# Altium schematic sheet 06-Front Panel SFP_2.SchDoc("06-Front Panel SFP_2")
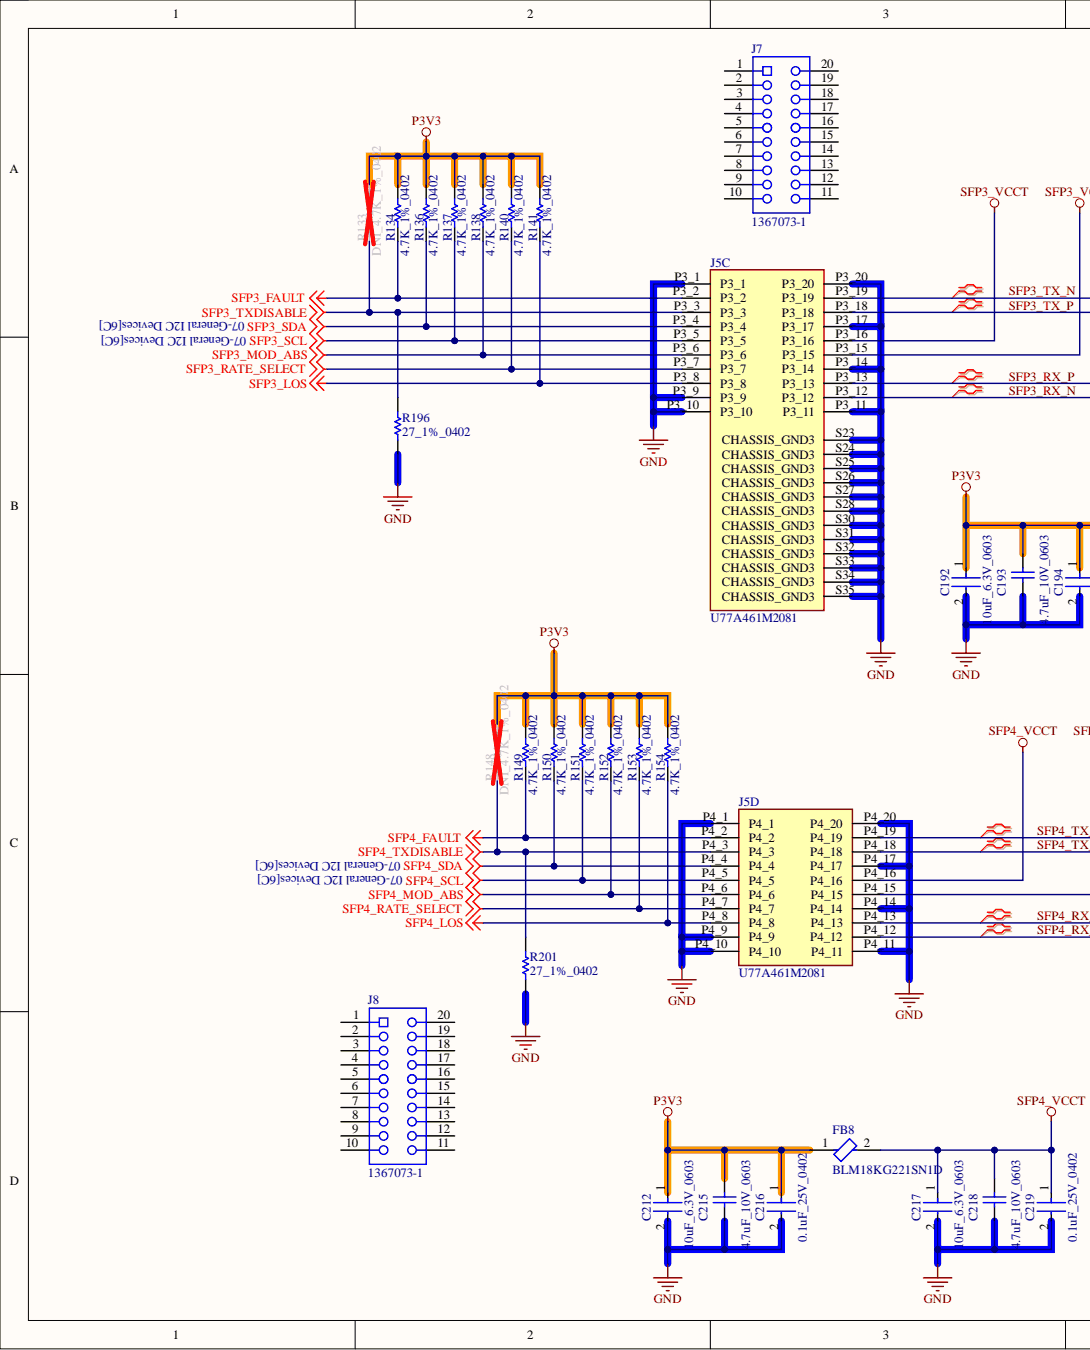
[diagram p0: 06-Front Panel SFP_2.SchDoc, left]
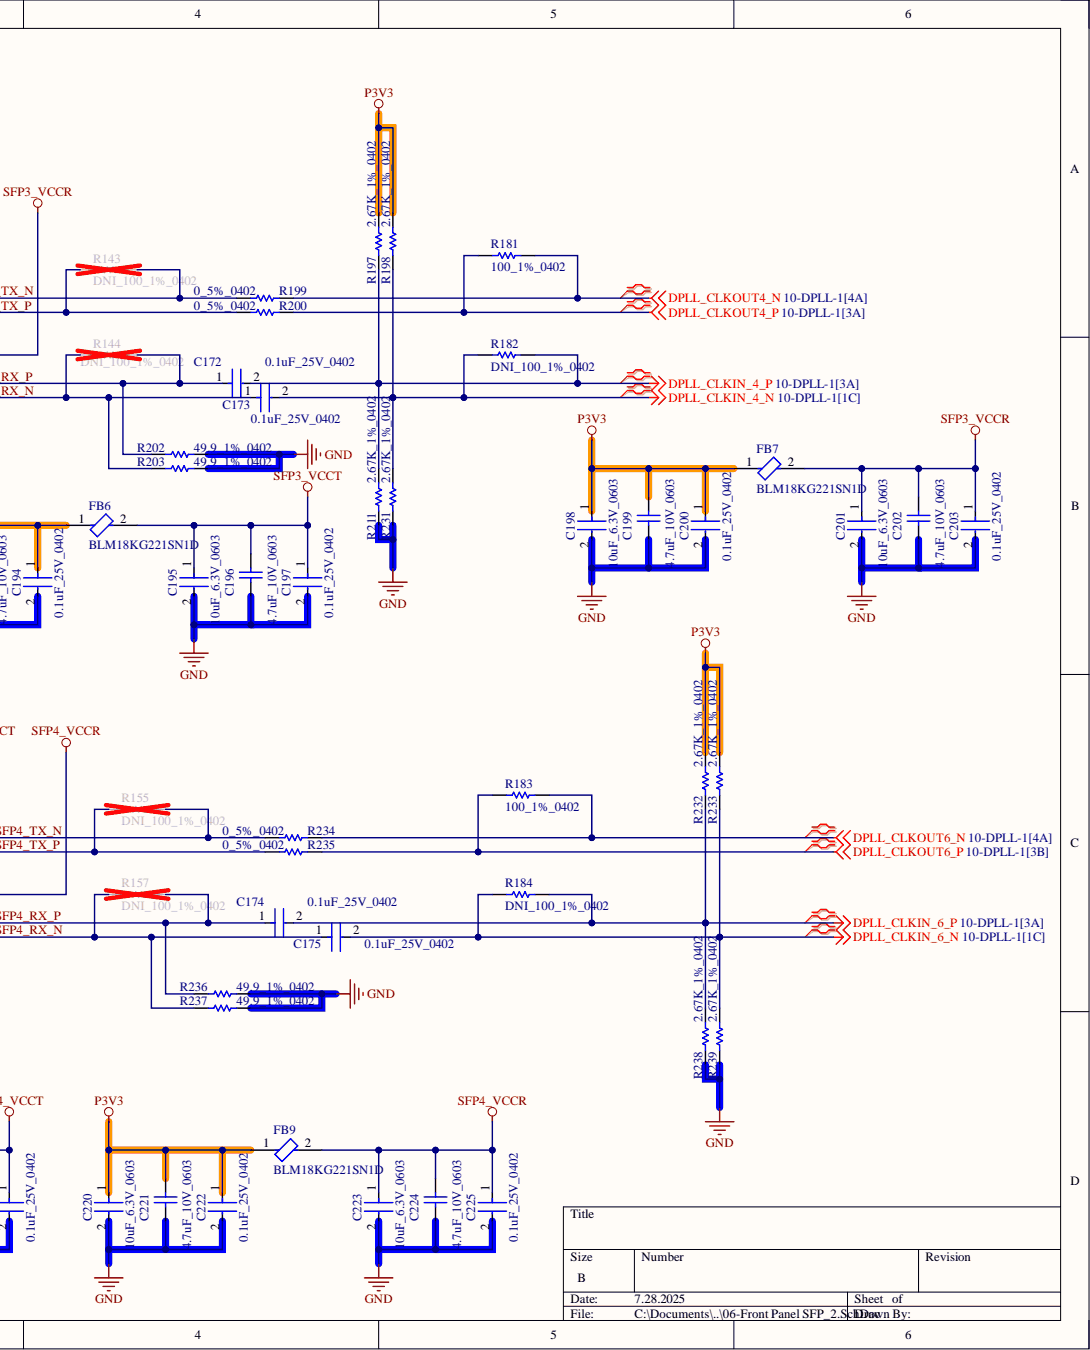
[diagram p1: 06-Front Panel SFP_2.SchDoc, right]

## Parts
C172  0.1uF_25V_0402  [FP-0402-L_1_0_1-W_0_5_0_1-IPC_C]
C173  0.1uF_25V_0402  [FP-0402-L_1_0_1-W_0_5_0_1-IPC_C]
C174  0.1uF_25V_0402  [FP-0402-L_1_0_1-W_0_5_0_1-IPC_C]
C175  0.1uF_25V_0402  [FP-0402-L_1_0_1-W_0_5_0_1-IPC_C]
C192  10uF_6.3V_0603  [FP-C0603C106M9PACTU-MFG]
C193  4.7uF_10V_0603  [CAPC1608X87X35NL15T15]
C194  0.1uF_25V_0402  [FP-0402-L_1_0_1-W_0_5_0_1-IPC_C]
C195  10uF_6.3V_0603  [FP-C0603C106M9PACTU-MFG]
C196  4.7uF_10V_0603  [CAPC1608X87X35NL15T15]
C197  0.1uF_25V_0402  [FP-0402-L_1_0_1-W_0_5_0_1-IPC_C]
C198  10uF_6.3V_0603  [FP-C0603C106M9PACTU-MFG]
C199  4.7uF_10V_0603  [CAPC1608X87X35NL15T15]
C200  0.1uF_25V_0402  [FP-0402-L_1_0_1-W_0_5_0_1-IPC_C]
C201  10uF_6.3V_0603  [FP-C0603C106M9PACTU-MFG]
C202  4.7uF_10V_0603  [CAPC1608X87X35NL15T15]
C203  0.1uF_25V_0402  [FP-0402-L_1_0_1-W_0_5_0_1-IPC_C]
C212  10uF_6.3V_0603  [FP-C0603C106M9PACTU-MFG]
C215  4.7uF_10V_0603  [CAPC1608X87X35NL15T15]
C216  0.1uF_25V_0402  [FP-0402-L_1_0_1-W_0_5_0_1-IPC_C]
C217  10uF_6.3V_0603  [FP-C0603C106M9PACTU-MFG]
C218  4.7uF_10V_0603  [CAPC1608X87X35NL15T15]
C219  0.1uF_25V_0402  [FP-0402-L_1_0_1-W_0_5_0_1-IPC_C]
C220  10uF_6.3V_0603  [FP-C0603C106M9PACTU-MFG]
C221  4.7uF_10V_0603  [CAPC1608X87X35NL15T15]
C222  0.1uF_25V_0402  [FP-0402-L_1_0_1-W_0_5_0_1-IPC_C]
C223  10uF_6.3V_0603  [FP-C0603C106M9PACTU-MFG]
C224  4.7uF_10V_0603  [CAPC1608X87X35NL15T15]
C225  0.1uF_25V_0402  [FP-0402-L_1_0_1-W_0_5_0_1-IPC_C]
FB6  BLM18KG221SN1D  [FP-BLM18-0_15-t0_8-IPC_B]
FB7  BLM18KG221SN1D  [FP-BLM18-0_15-t0_8-IPC_B]
FB8  BLM18KG221SN1D  [FP-BLM18-0_15-t0_8-IPC_B]
FB9  BLM18KG221SN1D  [FP-BLM18-0_15-t0_8-IPC_B]
J5  U77A461M2081  [AMPHENOL_U77A461M2081]  (parts C,D)
J7  1367073-1  [TECO-1367073-1_V]
J8  1367073-1  [TECO-1367073-1_V]
R133  DNI_4.7K_1%_0402  [RESC1005X40X25NL10T10]  (no BOM)
R134  4.7K_1%_0402  [RESC1005X40X25NL10T10]
R136  4.7K_1%_0402  [RESC1005X40X25NL10T10]
R137  4.7K_1%_0402  [RESC1005X40X25NL10T10]
R138  4.7K_1%_0402  [RESC1005X40X25NL10T10]
R140  4.7K_1%_0402  [RESC1005X40X25NL10T10]
R141  4.7K_1%_0402  [RESC1005X40X25NL10T10]
R143  DNI_100_1%_0402  [RESC1005X40X25NL05T10]  (no BOM)
R144  DNI_100_1%_0402  [RESC1005X40X25NL05T10]  (no BOM)
R148  DNI_4.7K_1%_0402  [RESC1005X40X25NL10T10]  (no BOM)
R149  4.7K_1%_0402  [RESC1005X40X25NL10T10]
R150  4.7K_1%_0402  [RESC1005X40X25NL10T10]
R151  4.7K_1%_0402  [RESC1005X40X25NL10T10]
R152  4.7K_1%_0402  [RESC1005X40X25NL10T10]
R153  4.7K_1%_0402  [RESC1005X40X25NL10T10]
R154  4.7K_1%_0402  [RESC1005X40X25NL10T10]
R155  DNI_100_1%_0402  [RESC1005X40X25NL05T10]  (no BOM)
R157  DNI_100_1%_0402  [RESC1005X40X25NL05T10]  (no BOM)
R181  100_1%_0402  [RESC1005X40X25NL05T10]
R182  DNI_100_1%_0402  [RESC1005X40X25NL05T10]  (no BOM)
R183  100_1%_0402  [RESC1005X40X25NL05T10]
R184  DNI_100_1%_0402  [RESC1005X40X25NL05T10]  (no BOM)
R196  27_1%_0402  [RESC1005X40X25NL05T05]
R197  2.67K_1%_0402  [RESC1005X40X25NL05T10]
R198  2.67K_1%_0402  [RESC1005X40X25NL05T10]
R199  0_5%_0402  [RESC1005X40X25LL10T10]
R200  0_5%_0402  [RESC1005X40X25LL10T10]
R201  27_1%_0402  [RESC1005X40X25NL05T05]
R202  49.9_1%_0402  [RESC1005X40X25NL10T10]
R203  49.9_1%_0402  [RESC1005X40X25NL10T10]
R211  2.67K_1%_0402  [RESC1005X40X25NL05T10]
R231  2.67K_1%_0402  [RESC1005X40X25NL05T10]
R232  2.67K_1%_0402  [RESC1005X40X25NL05T10]
R233  2.67K_1%_0402  [RESC1005X40X25NL05T10]
R234  0_5%_0402  [RESC1005X40X25LL10T10]
R235  0_5%_0402  [RESC1005X40X25LL10T10]
R236  49.9_1%_0402  [RESC1005X40X25NL10T10]
R237  49.9_1%_0402  [RESC1005X40X25NL10T10]
R238  2.67K_1%_0402  [RESC1005X40X25NL05T10]
R239  2.67K_1%_0402  [RESC1005X40X25NL05T10]

## Nets
DPLL_CLKIN_4_N: C173.2 R182.1 R198.1 R231.2
DPLL_CLKIN_4_P: C172.2 R182.2 R197.1 R211.2
DPLL_CLKIN_6_N: C175.2 R184.1 R233.1 R239.2
DPLL_CLKIN_6_P: C174.2 R184.2 R232.1 R238.2
DPLL_CLKOUT4_N: R181.2 R199.2
DPLL_CLKOUT4_P: R181.1 R200.2
DPLL_CLKOUT6_N: R183.2 R234.2
DPLL_CLKOUT6_P: R183.1 R235.2
GND: C192.2 C193.1 C194.2 C195.2 C196.1 C197.2 C198.2 C199.1 C200.2 C201.2 C202.1 C203.2 C212.2 C215.1 C216.2 C217.2 C218.1 C219.2 C220.2 C221.1 C222.2 C223.2 C224.1 C225.2 J5.P3_1 J5.P3_9 J5.P3_10 J5.P3_11 J5.P3_14 J5.P3_17 J5.P3_20 J5.P4_1 J5.P4_9 J5.P4_10 J5.P4_11 J5.P4_14 J5.P4_17 J5.P4_20 J5.S23(CHASSIS_GND3) J5.S24(CHASSIS_GND3) J5.S25(CHASSIS_GND3) J5.S26(CHASSIS_GND3) J5.S27(CHASSIS_GND3) J5.S28(CHASSIS_GND3) J5.S30(CHASSIS_GND3) J5.S31(CHASSIS_GND3) J5.S32(CHASSIS_GND3) J5.S33(CHASSIS_GND3) J5.S34(CHASSIS_GND3) J5.S35(CHASSIS_GND3) R196.1 R201.1 R202.2 R203.2 R211.1 R231.1 R236.2 R237.2 R238.1 R239.1
P3V3: C192.1 C193.2 C194.1 C198.1 C199.2 C200.1 C212.1 C215.2 C216.1 C220.1 C221.2 C222.1 FB6.1 FB7.1 FB8.1 FB9.1 R133.1 R134.1 R136.1 R137.1 R138.1 R140.1 R141.1 R148.1 R149.1 R150.1 R151.1 R152.1 R153.1 R154.1 R197.2 R198.2 R232.2 R233.2
SFP3_FAULT: J5.P3_2 R134.2
SFP3_LOS: J5.P3_8 R141.2
SFP3_MOD_ABS: J5.P3_6 R138.2
SFP3_RATE_SELECT: J5.P3_7 R140.2
SFP3_SCL: J5.P3_5 R137.2
SFP3_SDA: J5.P3_4 R136.2
SFP3_TXDISABLE: J5.P3_3 R133.2 R196.2
SFP3_VCCR: C201.1 C202.2 C203.1 FB7.2 J5.P3_15
SFP3_VCCT: C195.1 C196.2 C197.1 FB6.2 J5.P3_16
SFP4_FAULT: J5.P4_2 R149.2
SFP4_LOS: J5.P4_8 R154.2
SFP4_MOD_ABS: J5.P4_6 R152.2
SFP4_RATE_SELECT: J5.P4_7 R153.2
SFP4_SCL: J5.P4_5 R151.2
SFP4_SDA: J5.P4_4 R150.2
SFP4_TXDISABLE: J5.P4_3 R148.2 R201.2
SFP4_VCCR: C223.1 C224.2 C225.1 FB9.2 J5.P4_15
SFP4_VCCT: C217.1 C218.2 C219.1 FB8.2 J5.P4_16
SFP3_RX_N: C173.1 J5.P3_12 R144.1 R203.1
SFP3_RX_P: C172.1 J5.P3_13 R144.2 R202.1
SFP3_TX_N: J5.P3_19 R143.2 R199.1
SFP3_TX_P: J5.P3_18 R143.1 R200.1
SFP4_RX_N: C175.1 J5.P4_12 R157.1 R237.1
SFP4_RX_P: C174.1 J5.P4_13 R157.2 R236.1
SFP4_TX_N: J5.P4_19 R155.2 R234.1
SFP4_TX_P: J5.P4_18 R155.1 R235.1

Not connected: J7 1 2 3 4 5 6 7 8 9 10 11 12 13 14 15 16 17 18 19 20; J8 1 2 3 4 5 6 7 8 9 10 11 12 13 14 15 16 17 18 19 20

## Library data of the parts
C172-C175 C194 C197 C200 C203 C216 C219 C222 C225 = CMP-2008-02519-2: General Purpose Ceramic Capacitor, 0402, 100nF, 10%, X7R, 15%, 25V; Capacitance: 100nF; Case Code (Imperial): 0402; Case Code (Metric): 1005; Case/Package: 0402; Component Kind: Standard; Construction: Flat; Depth: 0.5mm; Dielectric: X7R; Lead Free: Lead Free; Length: 1mm; Library Name: Altium Content Vault; Max Operating Temperature: 125°C; Min Operating Temperature: -55°C; Mount: Surface Mount; Number of Pins: 2; Package Quantity: 1; Packaging: Tape and Reel; Radiation Hardening: No; REACH SVHC: No SVHC; RoHS Compliant: Yes; Series: -; Termination: SMD/SMT; Thickness: 0.022inch; Tolerance: 10%; Voltage: 25V; Voltage Rating: 25V; Voltage Rating (DC): 25V; Width: 0.02inch
C192 C195 C198 C201 C212 C217 C220 C223 = CMP-2006-02285-3: CAP CER 10UF 6.3V X5R 0603; Manufacturer: Kemet; Manufacturer Part Number: C0603C106M9PACTU; Material: Ceramic; Temperature Coefficient: 15%; Tolerance: 20%; Automotive: No; Capacitance: 10uF; Category: Cap; Component Kind: Standard; ComponentLink2Description: Datasheet; ComponentLink2URL: http://www.kemet.com/Lists/ProductCatalog/Attachments/19/KEM_C1006_X5R_SMD.pdf; Device Class L1: Passive Components; Device Class L2: Capacitors; Device Class L3: Ceramic Capacitors; Digikey Description: CAP CER 10UF 6.3V X5R 0603; Height: 0.9mm; Ipc Land Pattern Name: CAPC16080X80; Lead Free: Yes; Library Name: Altium Content Vault; Mouser Description: In a Pack of 10, KEMET 0603 (1608M) 10µF MLCC 6.3V dc ±20% SMD C0603C106M9PACTU; Octopart: https://octopart.com/c0603c106m9pactu-kemet-8375727; Package: C0603C106M9PACTU; RoHS: TRUE; Temperature: -55°C to +85°C; Temperature Characteristic: X5R; Temperature Range High: +85°C; Temperature Range Low: -55°C; Value: 10uF; Voltage Rating: 6.3V
C193 C196 C199 C202 C215 C218 C221 C224 = CMP-2006-03238-1: Manufacturer: Kemet; Part Number: C0603C475K8PACTU; Component Kind: Standard; Library Name: Altium Content Vault
FB6-FB9 = CMP-0686-00556-7: Chip Ferrite Bead, 0603, 220Ω @ 100MHz, 0.05Ω, 25%, 2.2A; DC Resistance (DCR): 50mR; Impedance: 220R; Number of Circuits: 1; Case Code (Imperial): 0603; Case Code (Metric): 1608; Case/Package: 0603; Component Kind: Standard; Core Material: Ferrite; Current Rating: 2.2A; DC Current: 2.2A; DC Resistance-Max: 50mR; Depth: 0.8mm; Height: 0.8mm; Inductor Type: FERRITE BEAD; Lead Free: Lead Free; Length: 1.6mm; Library Name: Altium Content Vault; Material: Ferrite; Max Current Rating: 2.2A; Max DC Current: 2.2A; Max Operating Temperature: 125°C; Military Standard: Not; Min Operating Temperature: -55°C; Number of Lines: 1; Number of Pins: 2; Package Quantity: 1; Packaging: Tape and Reel; Radiation Hardening: No; REACH SVHC: No SVHC; RoHS Compliant: Yes; Series: BLM18K; Termination: SMD/SMT; Test Frequency: 100MHz; Tolerance: 25%; Weight: 7.1E-05oz; Width: 0.8mm
J5 = U77A461M2081: MF: AMPHENOL ICC / FCI; Availability: Unavailable; Component Kind: Standard; Library Name: U77A461M2081.SchLib; MP: U77A461M2081; Package: None; Price: None
J7 J8 = CMP-2000-05488-1: Female Connector, Pitch 0.8 mm, 2 x 10 Position, Height 5.4 mm, -55 to 85 degC, RoHS, Tape and Reel; Manufacturer: TE Connectivity; 3D Model: 1367073-1.pdf; Associated Product: A103997CT-ND  -CONNSFPCAGESINGLEW/OPIPE  A103997TR-ND  -CONNSFPCAGESINGLEW/OPIPE  1367645-3-ND  -CONNCAGE&HEATSINKSGLPORTTIN  1367645-2-ND  -CONNCAGE&HEATSINKSGLPORTTIN  1888424-1-ND  -CONNCAGE1X2SFPENHANCEDEMI  1367643-1-ND  -CONNCAGESNGLPORTDBLSIDETIN  1489962-1-ND  -CONNSFPCAGESINGLEW/OPIPE  1367645-1-ND  -CONNCAGE&HEATSINKSGLPORTTIN  A99375-ND  -CONNSFPCAGE1X4PIN-IN-PASTE  A97412CT-ND  -CONNSFPLOWERCAGEPCB  More...; Connector Style: Receptacle; Connector Type: SFP; Dynamic Catalog: SFPConnectors; Featured Product: HighSpeedPluggableI-OSolutions; Mating Products: 516-2084-ND  -TXRXOPTICALSFF1.25GBDISCSI; Number of Positions: 20; Other Names: A97430DKR; Product Training Modules: HighSpeedPluggableI/OSolutions; Category: Connectors,Interconnects; Component Kind: Standard; Contact Finish: Gold; Contact Finish Thickness: 15µin(0.38µm); Datasheet URL: http://www.te.com/commerce/DocumentDelivery/DDEController?Action=showdoc&DocId=Customer+Drawing%7F1367073%7FK%7Fpdf%7FEnglish%7FENG_CD_1367073_K.pdf%7F1367073-1; Datasheet Version: Rev. K, 12/2012; Family: PluggableConnectors; Library Name: Altium Content Vault; Manufacturer URL: http://www.te.com/; Mounting Technology: SurfaceMount; Mounting Type: SurfaceMount,RightAngle; Package Description: Female Connector, Pitch 0.8 mm, 2 x 10 Position, Height 5.4 mm; Package Reference: 1367073-1; Packaging: Digi-Reel®; RoHS Information: 1367073-1StatementofCompliance; Standard Package: 1; Termination: Solder
R133 R134 R136-R138 R140 R141 R148-R154 = CMP-2002-05217-1: Manufacturer: Bourns; Part Number: CR0402-FX-4701GLF; Library Name: Altium Content Vault
  R134 R136-R138 R140 R141 R149-R154: Component Kind: Standard
R143 R144 R155 R157 R181-R184 = CMP-2002-07853-1: Manufacturer: Yageo; Part Number: RC0402FR-13100RL; Library Name: Altium Content Vault
  R181 R183: Component Kind: Standard
R196 R201 = CMP-2002-08434-1: Manufacturer: Vishay Dale; Part Number: CRCW040227R0FKED; Component Kind: Standard; Library Name: Altium Content Vault
R197 R198 R211 R231-R233 R238 R239 = CMP-2002-07574-1: Manufacturer: Yageo; Part Number: RC0402FR-072K67L; Component Kind: Standard; Library Name: Altium Content Vault
R199 R200 R234 R235 = CMP-2002-04569-1: Manufacturer: KOA Speer; Part Number: RK73Z1ETTP; Component Kind: Standard; Library Name: Altium Content Vault
R202 R203 R236 R237 = CMP-2002-04448-1: Manufacturer: KOA Speer; Part Number: RK73H1ETTP49R9F; Component Kind: Standard; Library Name: Altium Content Vault
